# Altium schematic sheet [3]- MCU.SchDoc("[3]- MCU")
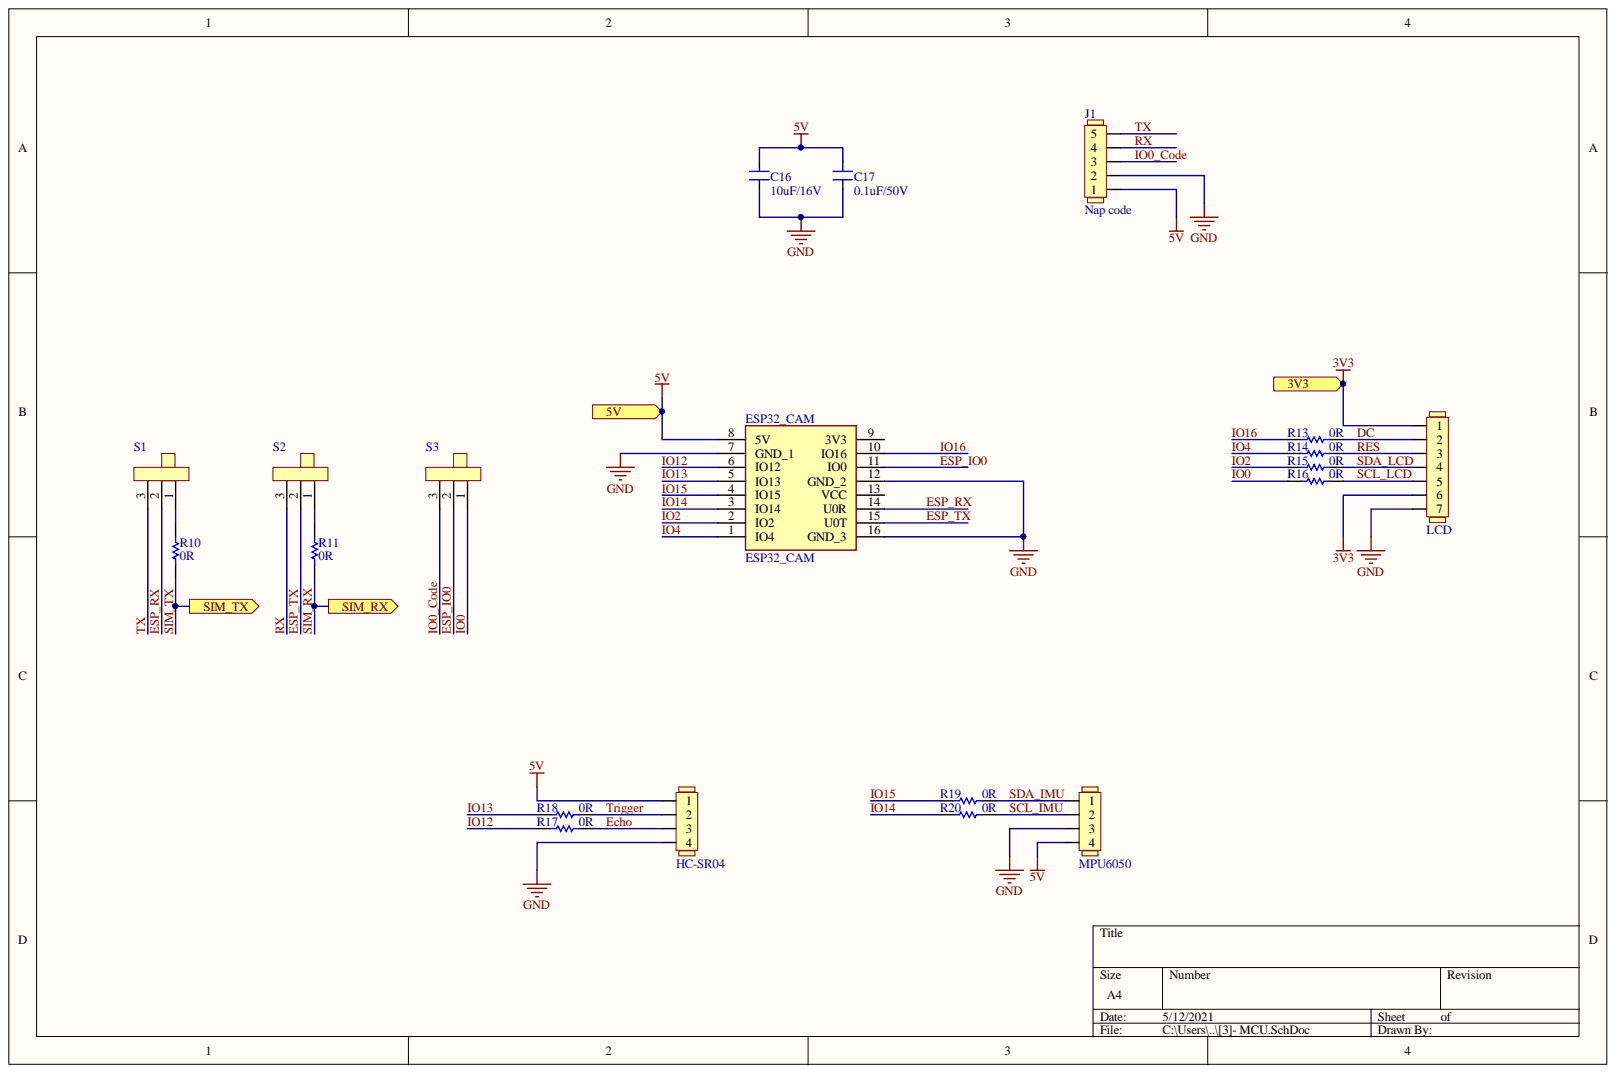
[diagram p0: [3]- MCU.SchDoc]

## Parts
C16  10uF/16V  [CAP 0603(1508)]
C17  0.1uF/50V  [CAP 0603(1508)]
ESP32_CAM  ESP32_CAM  [ESP32-CAM]
HC-SR04  Header 4  [HEADER x4]  (value not drawn)
J1  Nap code  [HEADER x5]
LCD  Header 7  [HEADER x7]  (value not drawn)
MPU6050  Header 4  [HEADER x4]  (value not drawn)
R10  0R  [RES 0603(1608)]
R11  0R  [RES 0603(1608)]
R13  0R  [RES 0603(1608)]
R14  0R  [RES 0603(1608)]
R15  0R  [RES 0603(1608)]
R16  0R  [RES 0603(1608)]
R17  0R  [RES 0603(1608)]
R18  0R  [RES 0603(1608)]
R19  0R  [RES 0603(1608)]
R20  0R  [RES 0603(1608)]
S1  MK12C02  [MK12C02]  (value not drawn)
S2  MK12C02  [MK12C02]  (value not drawn)
S3  MK12C02  [MK12C02]  (value not drawn)

## Ports
3V3, 5V, SIM_RX, SIM_TX

## Nets
GND: C16.1 C17.1 ESP32_CAM.7(GND_1) ESP32_CAM.12(GND_2) ESP32_CAM.16(GND_3) HC-SR04.4 J1.2 LCD.7 MPU6050.3
3V3: LCD.1 LCD.6 ; port 3V3
5V: C16.2 C17.2 ESP32_CAM.8(5V) HC-SR04.1 J1.1 MPU6050.4 ; port 5V
DC: LCD.2 R13.1
Echo: HC-SR04.3 R17.1
ESP_IO0: ESP32_CAM.11(IO0) S3.2
ESP_RX: ESP32_CAM.14(U0R) S1.2
ESP_TX: ESP32_CAM.15(U0T) S2.2
IO0: R16.2 S3.1
IO0_Code: J1.3 S3.3
IO2: ESP32_CAM.2(IO2) R15.2
IO4: ESP32_CAM.1(IO4) R14.2
IO12: ESP32_CAM.6(IO12) R17.2
IO13: ESP32_CAM.5(IO13) R18.2
IO14: ESP32_CAM.3(IO14) R20.2
IO15: ESP32_CAM.4(IO15) R19.2
IO16: ESP32_CAM.10(IO16) R13.2
RES: LCD.3 R14.1
RX: J1.4 S2.3
SCL_IMU: MPU6050.2 R20.1
SCL_LCD: LCD.5 R16.1
SDA_IMU: MPU6050.1 R19.1
SDA_LCD: LCD.4 R15.1
SIM_RX: R11.2 ; port SIM_RX
SIM_TX: R10.2 ; port SIM_TX
Trigger: HC-SR04.2 R18.1
TX: J1.5 S1.3
NetR10_1: R10.1 S1.1
NetR11_1: R11.1 S2.1

Not connected: ESP32_CAM 9(3V3) 13(VCC)

## Library data of the parts
C16 = CAP_CER_10uF_16V_NPOL: Ceramic Capacitor 10uF/16V (+-10% TH)/(+10%SMD); Component Kind: Standard; : 10uF/35V; Library Name: Duc.SchLib
C17 = CAP_CER_0.1uF_50V_NPOL_1: Ceramic Capacitor 1nF/50V (+-20% TH)/(+10%SMD); Component Kind: Standard; Library Name: Duc.SchLib
J1 = Header 5: Component Kind: Standard; Library Name: Duc.SchLib
R10 R11 R13-R20 = Resistor 0603: Description: Resistor 0603; Component Kind: Standard; Library Name: Duc.SchLib
ESP32_CAM = ESP32_CAM: Component Kind: Standard; Library Name: Duc.SchLib
HC-SR04 MPU6050 = Header 4: Component Kind: Standard; Library Name: Duc.SchLib
LCD = Header 7: Component Kind: Standard; Library Name: Duc.SchLib
S1-S3 = MK12C02: Library Name: BYTECH_HW_LIB_2019.IntLib; Component Kind: Standard
